# Altium schematic sheet POWER.SchDoc
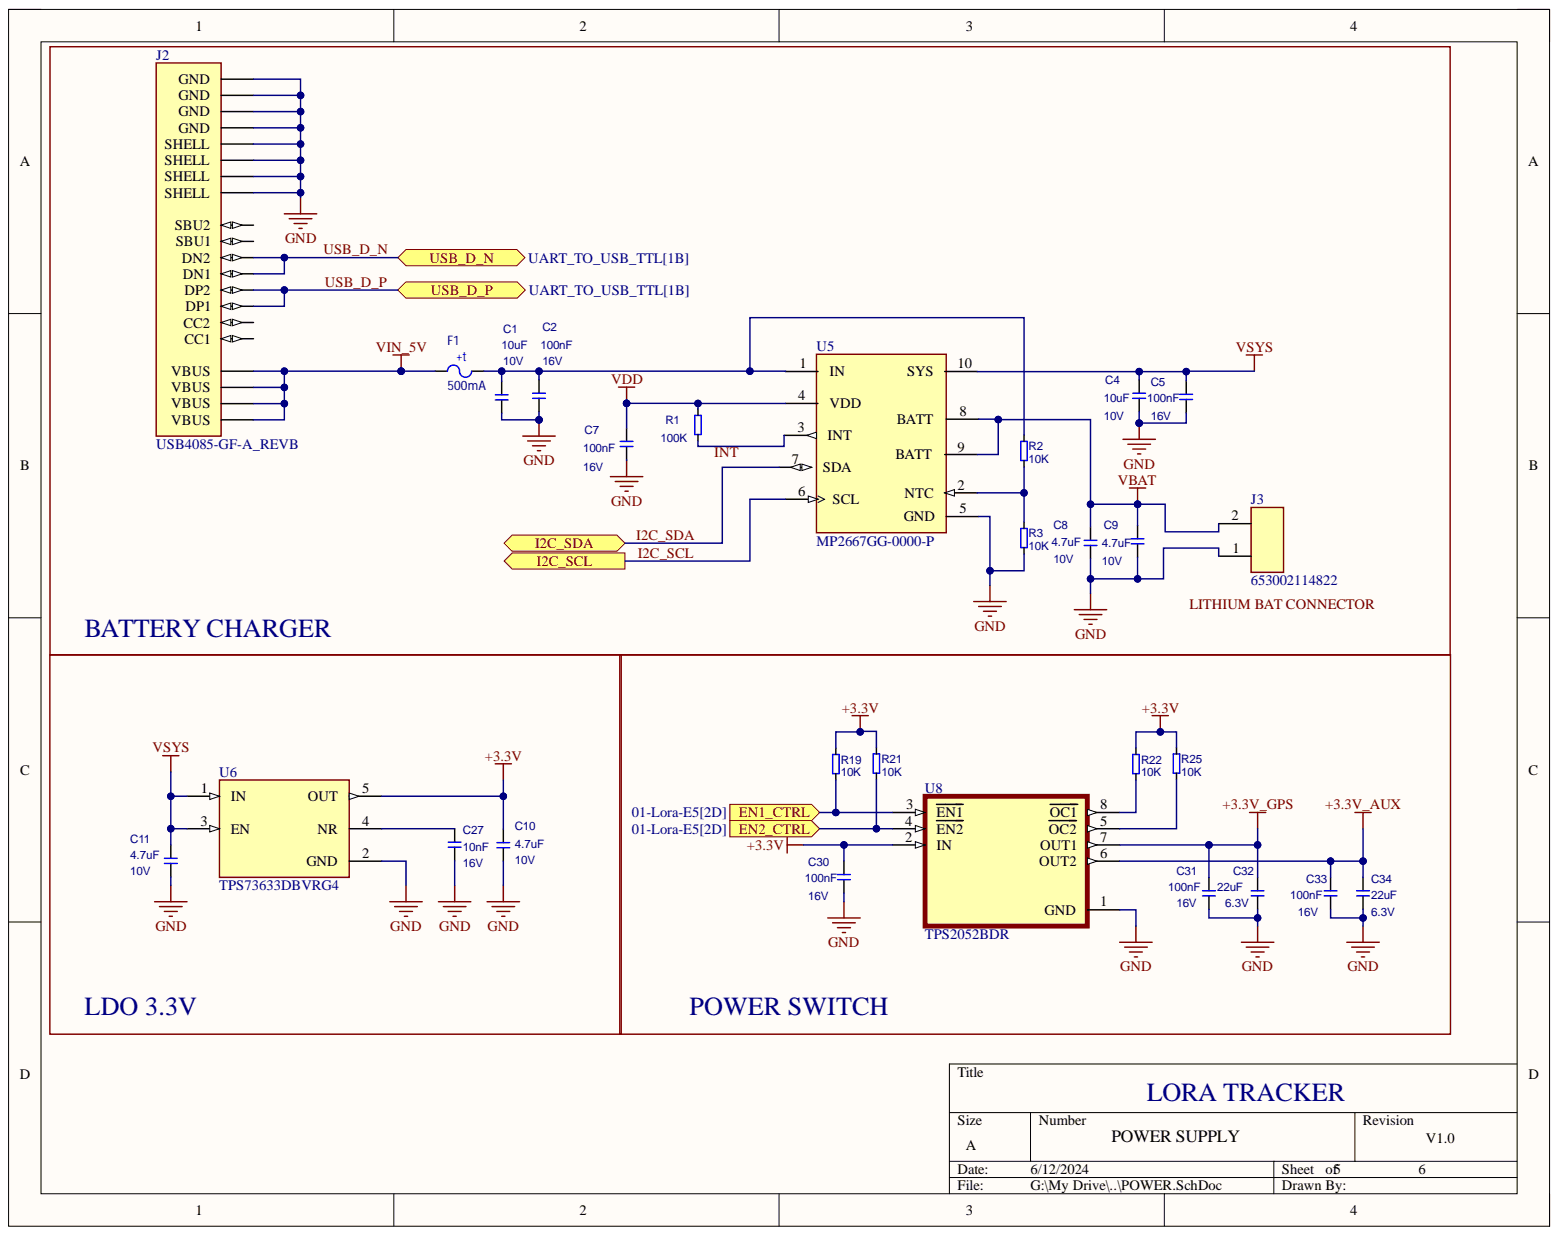
[diagram p0: POWER.SchDoc]

## Parts
C1  10uF  Rating: 10V  [T_CAPC0603X33N]
C2  100nF  Rating: 16V  [CAPC1005X60N]
C4  10uF  Rating: 10V  [T_CAPC0603X33N]
C5  100nF  Rating: 16V  [CAPC1005X60N]
C7  100nF  Rating: 16V  [CAPC1005X60N]
C8  4.7uF  Rating: 10V  [T_CAPC0603X33N]
C9  4.7uF  [T_CAPC0603X33N]
C10  4.7uF  Rating: 10V  [T_CAPC0603X33N]
C11  4.7uF  Rating: 10V  [T_CAPC0603X33N]
C27  10nF  Rating: 16V  [CAPC1005X60N]
C30  100nF  Rating: 16V  [CAPC1005X60N]
C31  100nF  Rating: 16V  [CAPC1005X60N]
C32  22uF  Rating: 6.3V  [CAPC1005X60N]
C33  100nF  Rating: 16V  [CAPC1005X60N]
C34  22uF  Rating: 6.3V  [CAPC1005X60N]
F1  500mA  [FUSC2113X180N]
J2  USB4085-GF-A_REVB  [GCT_USB4085-GF-A_REVB]
J3  653002114822  [653002114822]
R1  100K  [RESC1005X40N]
R2  10K  [RESC1005X40N]
R3  10K  [RESC1005X40N]
R19  10K  [RESC1005X40N]
R21  10K  [RESC1005X40N]
R22  10K  [RESC1005X40N]
R25  10K  [RESC1005X40N]
U5  MP2667GG-0000-P  [QFN50P200X200X105-10N]
U6  TPS73633DBVRG4  [SOT95P280X145-5N]
U8  TPS2052BDR  [SOIC127P599X175-8N]

## Ports
EN1_CTRL, EN2_CTRL, I2C_SCL, I2C_SDA, USB_D_N, USB_D_P

## Nets
+3.3V: C10.2 C30.2 R19.2 R21.2 R22.2 R25.2 U6.5(OUT) U8.2(IN)
+3.3V_AUX: C33.2 C34.2 U8.6(OUT2)
+3.3V_GPS: C31.2 C32.2 U8.7(OUT1)
GND: C1.1 C2.1 C4.1 C5.1 C7.1 C8.1 C9.1 C10.1 C11.1 C27.1 C30.1 C31.1 C32.1 C33.1 C34.1 J2.A1(GND) J2.A12(GND) J2.B1(GND) J2.B12(GND) J2.S1(SHELL) J2.S2(SHELL) J2.S3(SHELL) J2.S4(SHELL) J3.1 R3.1 U5.5(GND) U6.2(GND) U8.1(GND)
VBAT: C8.2 C9.2 J3.2 U5.8(BATT) U5.9(BATT)
VDD: C7.2 R1.2 U5.4(VDD)
VIN_5V: F1.1 J2.A4(VBUS) J2.A9(VBUS) J2.B4(VBUS) J2.B9(VBUS)
VSYS: C4.2 C5.2 C11.2 U5.10(SYS) U6.1(IN) U6.3(EN)
EN1_CTRL: R19.1 U8.3(EN1) ; port EN1_CTRL
EN2_CTRL: R21.1 U8.4(EN2) ; port EN2_CTRL
I2C_SCL: U5.6(SCL) ; port I2C_SCL
I2C_SDA: U5.7(SDA) ; port I2C_SDA
USB_D_N: J2.A7(DN1) J2.B7(DN2) ; port USB_D_N
USB_D_P: J2.A6(DP1) J2.B6(DP2) ; port USB_D_P
NetC1_2: C1.2 C2.2 F1.2 R2.2 U5.1(IN)
NetC27_2: C27.2 U6.4(NR)
NetR1_1: R1.1 U5.3(INT)
NetR2_1: R2.1 R3.2 U5.2(NTC)
NetR22_1: R22.1 U8.8(OC1)
NetR25_1: R25.1 U8.5(OC2)
INT:

Not connected: J2 A5(CC1) A8(SBU1) B5(CC2) B8(SBU2)

## Library data of the parts
C1 C4 = C-X5R-4.7uF-10V-10%-0603: Capacitor Ceramic X5R 10uF 10V 10% 0603; Manufacturer Name: Generic; MP: CC0603KRX5R6BB106; Component Kind: Standard; Library Name: Libary_battery-power.SchLib; Tolerance: 10%; Type: X5R; Value: 10uF
C2 C5 C7 C30 C31 C33 = C-X7R-100nF-16V-10%-0603: CAP CER 0.1UF 16V X7R 0402; MP: CC0402KRX7R7BB104; Tolerance: 10%; Component Kind: Standard; Library Name: Libary_battery-power.SchLib; Type: X7R; Value: 100nF
C8-C11 = C-X5R-4.7uF-10V-10%-0603: Capacitor Ceramic X5R 4.7uF 10V 10% 0603; Manufacturer Name: Generic; MP: CC0603KRX5R6BB475; Component Kind: Standard; Library Name: Libary_battery-power.SchLib; Tolerance: 10%; Type: X5R; Value: 4.7uF
  C9: Rating: 10V
C27 = C-X7R-100nF-16V-10%-0603: CAP CER 0402 10NF 16V X7R 10%; MP: CC0402KRX7R7BB103; Component Kind: Standard; Library Name: Libary_battery-power.SchLib; Tolerance: 10%; Type: X7R; Value: 10nF
C32 C34 = C-X7R-100nF-16V-10%-0603: CAP CER 22UF 6.3V X5R 0402; MP: 04026D226MAT2A; Tolerance: 20%; Component Kind: Standard; Library Name: Libary_battery-power.SchLib; Type: X5R; Value: 22uF
F1 = F_PTC: Fuse; MP: 0ZCK0050FF2E; Component Kind: Standard; Hold Current: 500mA; Library Name: Libary_battery-power.SchLib
J2 = USB4085-GF-A_REVB: USB-C (USB TYPE-C) USB 2.0 æ’åº§è¿žæŽ¥å™¨ 24 (16+8 Dummy) ä½é€šå­”ã€ç›´è§’ã€ä½Žæˆæœ¬ã€3.46mm å¤–å½¢ã€16 é’ˆã€æ°´å¹³ã€é¡¶éƒ¨å®‰è£…; MF: Global Connector Technology; Check_prices: https://www.snapeda.com/parts/USB4085-GF-A/Global+Connector+Technology/view-part/?ref=eda; Component Kind: Standard; Library Name: ESP32_Gateway_v1.0.SCHLIB; MP: USB4085-GF-A; Package: None; SnapEDA_Link: https://www.snapeda.com/parts/USB4085-GF-A/Global+Connector+Technology/view-part/?ref=snap
J3 = 653002114822: CONN, HEADER, 2POS, 1ROW, 1.25MM; Connector Systems:Wire-to-Board; Pitch Spacing:1.25mm; No. of Rows:1Rows; No. of Contacts:2Contacts; Contact Termination Type:Through Hole Straight; Product Range:WR-WTB Series RoHS Compliant: Yes; MF: Wurth Electronics; Availability: Not in stock; Check_prices: https://www.snapeda.com/parts/653002114822/Wurth+Elektronik/view-part/?ref=eda; Component Kind: Standard; Library Name: 653002114822.SchLib; MP: 653002114822; Package: None; Price: None; SnapEDA_Link: https://www.snapeda.com/parts/653002114822/Wurth+Elektronik/view-part/?ref=snap
R1 = R-10KR-63mW-5%-0603: RES 100K OHM 5% 1/16W 0402; MP: RC0402JR-13330RL; Rating: 63mW; Value: 330R; Component Kind: Standard; Library Name: Libary_battery-power.SchLib; Tolerance: 5%
R2 R3 R19 R21 R22 R25 = R-22R-63mW-5%-0402: Resistor 10K ohm 63mW 5% 0402; MP: RC0402JR-0710KL; Component Kind: Standard; Rating: 63mW; Tolerance: 5%; Value: 10K; Library Name: Iris.SchLib
U5 = MP2664GG-0000-Z: 500mA, 5V USB, I2C-ControlledBattery Charger with Power Path Managementfor Single-Cell Li-Ion Battery in QFN Package; MF: MPS; Availability: Unavailable; Component Kind: Standard; Library Name: MP2667GG.SchLib; MP: MP2667GG-0000-P; Package: Package Analog Devices; Price: None; SnapEDA_Link: https://www.snapeda.com/parts/MP2664GG-0000-Z/Monolithic%20Power%20Systems%2C%20Inc/view-part/4254948/?ref=snap
U6 = TPS73633DBVRG4: 400-mA, high-accuracy, ultra-low-dropout voltage regulator with reverse current protection and enable 5-SOT-23 -40 to 125; MF: {'manufacturer': u'Texas Instruments'}; Availability: Unavailable; Component Kind: Standard; Library Name: TPS73633DBVRG4.SchLib; MP: TPS73633DBVRG4; Package: None; Price: None
U8 = TPS2052BDR: Power Switch ICs - Power Distribution Current-Limited; Availability: Unavailable; Component Kind: Standard; Library Name: TPS2052BDR.IntLib; MP: TPS2052BDR; Package: None; Price: None
